# Altium schematic sheet 主控芯片.SchDoc
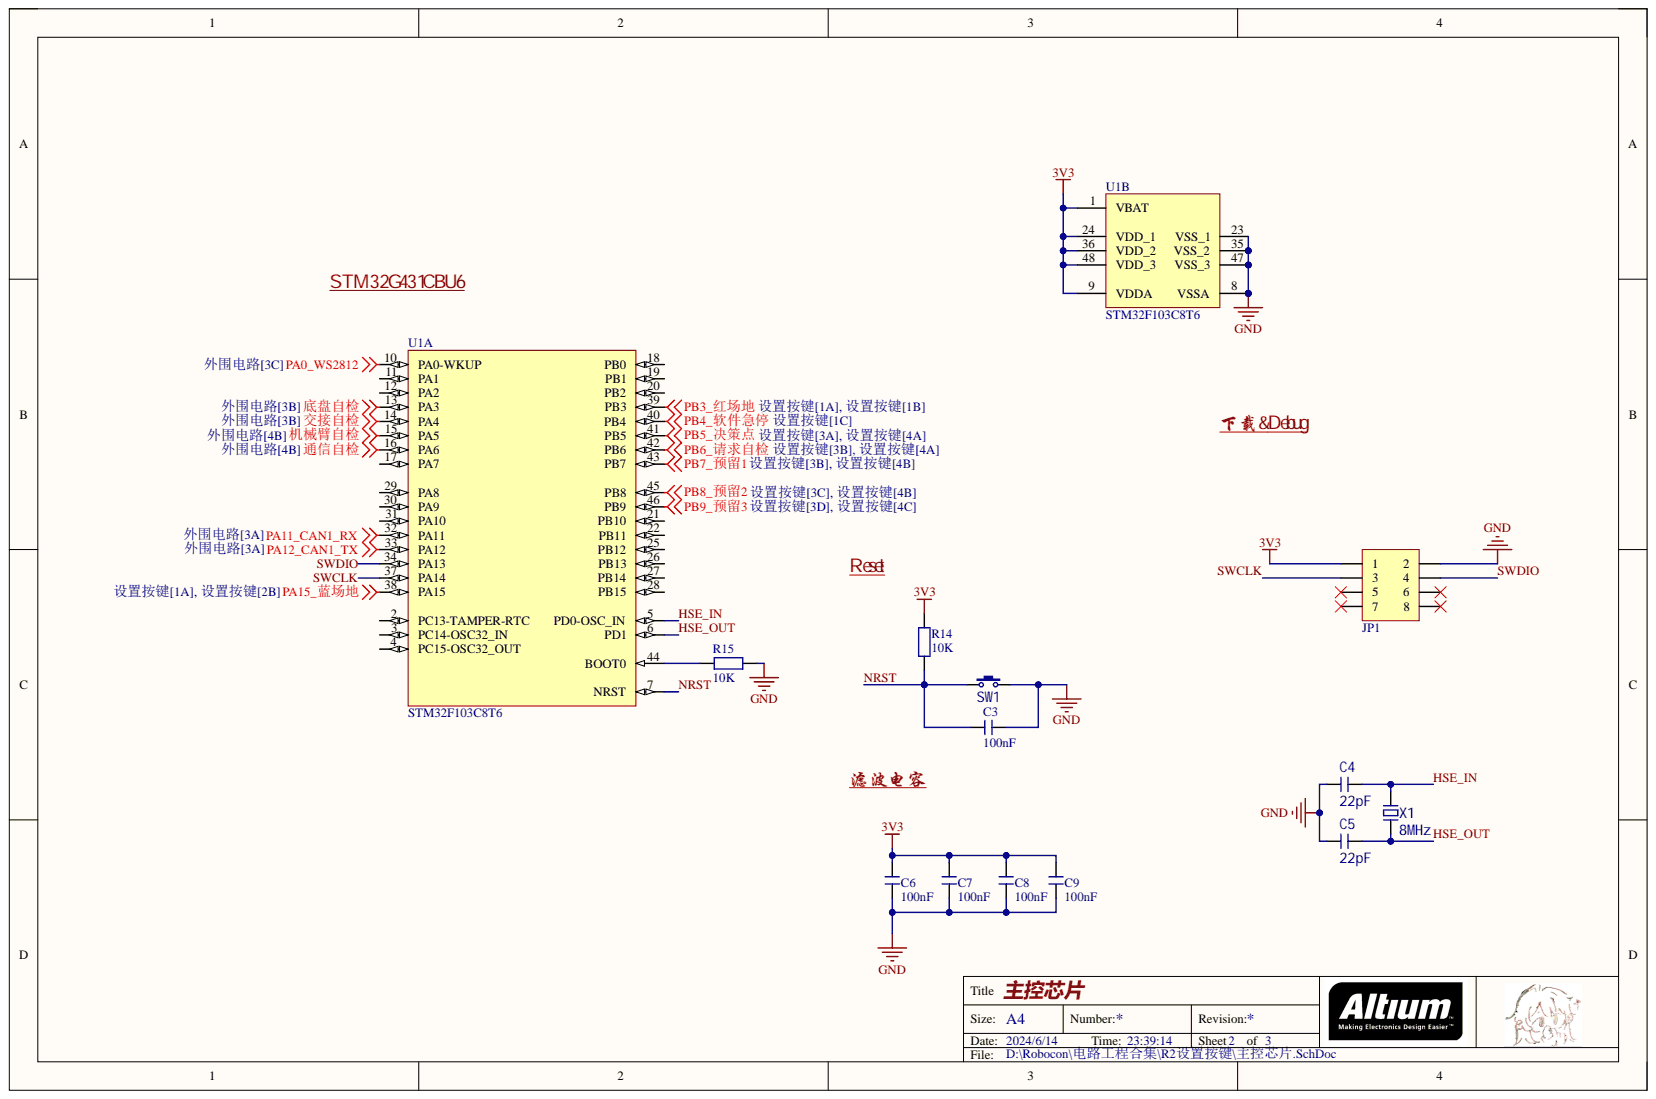
[diagram p0: 主控芯片.SchDoc]

## Parts
C3  100nF  [C 0603_L]
C4  22pF  [C 0603_L]
C5  22pF  [C 0603_L]
C6  100nF  [C 0603_L]
C7  100nF  [C 0603_L]
C8  100nF  [C 0603_L]
C9  100nF  [C 0603_L]
JP1  Header 4X2  [IDC2.54-LI-2x4P]  (value not drawn)
R14  10K  [R 0603_L]
R15  10K  [R 0603_L]
SW1  6x6x5  [TS 2PIN 6x6x4.8]  (value not drawn)
U1  STM32F103C8T6  [LQFP48_N]  (parts A,B)
X1  8MHz  [OSC 5032-2P]

## Nets
3V3: C6.2 C7.2 C8.2 C9.2 JP1.1 R14.2 U1.1(VBAT) U1.9(VDDA) U1.24(VDD_1) U1.36(VDD_2) U1.48(VDD_3)
GND: C3.2 C4.1 C5.1 C6.1 C7.1 C8.1 C9.1 JP1.2 R15.2 SW1.2 U1.8(VSSA) U1.23(VSS_1) U1.35(VSS_2) U1.47(VSS_3)
PA0_WS2812: U1.10(PA0-WKUP)
PA11_CAN1_RX: U1.32(PA11)
PA12_CAN1_TX: U1.33(PA12)
PA15_蓝场地: U1.38(PA15)
PB3_红场地: U1.39(PB3)
PB4_软件急停: U1.40(PB4)
PB5_决策点: U1.41(PB5)
PB6_请求自检: U1.42(PB6)
PB7_预留1: U1.43(PB7)
PB8_预留2: U1.45(PB8)
PB9_预留3: U1.46(PB9)
交接自检: U1.14(PA4)
底盘自检: U1.13(PA3)
机械臂自检: U1.15(PA5)
通信自检: U1.16(PA6)
HSE_IN: C4.2 U1.5(PD0-OSC_IN) X1.1
HSE_OUT: C5.2 U1.6(PD1) X1.2
NRST: C3.1 R14.1 SW1.1 U1.7(NRST)
SWCLK: JP1.3 U1.37(PA14)
SWDIO: JP1.4 U1.34(PA13)
NetR15_1: R15.1 U1.44(BOOT0)

Not connected: JP1 5 6 7 8; U1 2(PC13-TAMPER-RTC) 3(PC14-OSC32_IN) 4(PC15-OSC32_OUT) 11(PA1) 12(PA2) 17(PA7) 18(PB0) 19(PB1) 20(PB2) 21(PB10) 22(PB11) 25(PB12) 26(PB13) 27(PB14) 28(PB15) 29(PA8) 30(PA9) 31(PA10)

## Library data of the parts
C3 C6-C9 = C: 贴片电容; BOM名称: 无极性贴片电容; 淘宝ID: 封装库来自淘宝 ID：黄晶宝石; Component Kind: Standard; Library Name: 0.1 - 电阻-电容-电感.SchLib; 唯一出售店铺网址: https://shop463601444.taobao.com; 联系售后，技术支持: https://shop463601444.taobao.com
C4 C5 = C: 无极性贴片电容; 材质: X7R; BOM名称: 无极性贴片电容; Component Kind: Standard; Library Name: 3.1   - 电阻-电容-电感.SchLib
JP1 = Header 4X2: 4*2P接插件; 版权归属: 封装库来自淘宝 ID：黄晶宝石; BOM名称: 4*2P接插件; Component Kind: Standard; Library Name: 5.4 - 接插件-脚距2.54 (100mil) .SchLib; 学习资源: https://shop463601444.taobao.com
R14 R15 = R: 贴片电阻; 封装库来自淘宝卖家 ID：黄晶宝石: 其他店铺购入均为盗版，不享受售后技术支持服务，请立即申请退款; 版权归属: 来自淘宝 ID：黄晶宝石; BOM名称: 贴片电阻; Component Kind: Standard; Library Name: 0.1 - 电阻-电容-电感.SchLib; 联系售后: https://shop463601444.taobao.com
SW1 = TSW SMD-2Pin-6x6: 2脚贴片6x6无声硅胶轻触开关; BOM名称: 2脚轻触开关; Component Kind: Standard; Library Name: CMD_G474.SCHLIB
U1 = STM32F103C8T6: STM32 ARM-based 32-bit MCU Performance Line with 64 kB Flash, 20 kB Internal RAM, -40 to +85癈 Temperature, 48-Pin LQFP, Tray; Component Kind: Standard; ComponentLink1Description: Manufacturer URL; ComponentLink1URL: http://www.st.com/; ComponentLink2Description: STM32F103 Datasheet; ComponentLink2URL: http://www.st.com/internet/com/TECHNICAL_RESOURCES/TECHNICAL_LITERATURE/DATASHEET/CD00161566.pdf; ComponentLink3Description: STM32 Family Web Page; ComponentLink3URL: www.st.com/stm32; DatasheetVersion: rev13.0, Apr-2011; Library Name: 2.3 - STM32 F1系列单片机.SchLib; PackageDescription: 48-Pin Low-Profile Quad Flat Pack, 7 x 7 mm Body, 0.5 mm Pitch (LQFP48); PackageReference: LQFP48; PackageVersion: rev13, Apr-2011; 联系售后: https://shop463601444.taobao.com
X1 = XTAL-2P: 2脚无源脚晶振; 负载电容: CL20pF; BOM名称: 2脚无源脚晶振; Component Kind: Standard; Library Name: 10.1 - 保险丝-晶振.SchLib
